# Altium schematic sheet Core.SchDoc
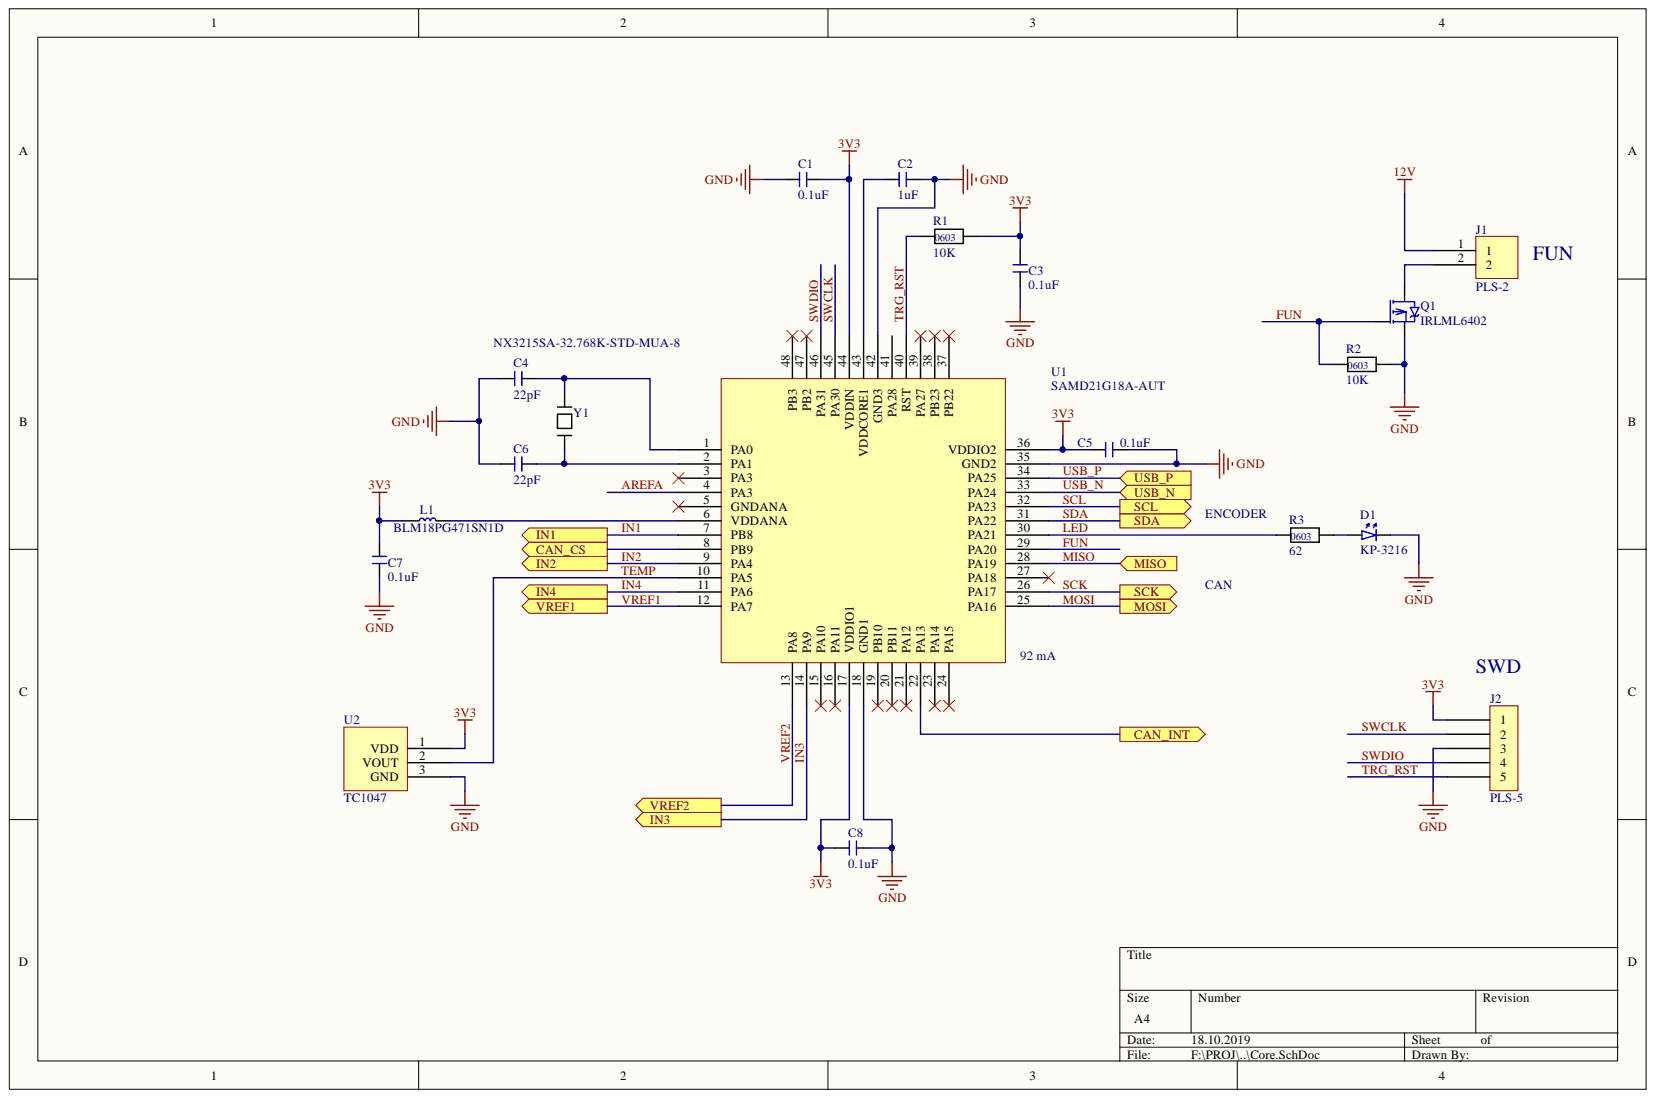
[diagram p0: Core.SchDoc]

## Parts
C1  0.1uF  [CHIP_C_0603]
C2  1uF  [CHIP_C_0603]
C3  0.1uF  [CHIP_C_0603]
C4  22pF  [CHIP_C_0603]
C5  0.1uF  [CHIP_C_0603]
C6  22pF  [CHIP_C_0603]
C7  0.1uF  [CHIP_C_0603]
C8  0.1uF  [CHIP_C_0603]
D1  KP-3216  [CHIP_D_1632]
J1  PLS-2  [PLS-2]
J2  PLS-5  [PLS2-5]
L1  BLM18PG471SN1D  [CHIP_R_0603]
Q1  IRLML6402  [SOT23]
R1  10K  [CHIP_R_0603]
R2  10K  [CHIP_R_0603]
R3  62  [CHIP_R_0603]
U1  SAMD21G18A-AUT  [LQFP48]
U2  TC1047  [SOT23]
Y1  NX3215SA-32.768K-STD-MUA-8  [NX3215SA]

## Ports
CAN_CS, CAN_INT, IN1, IN2, IN3, IN4, MISO, MOSI, SCK, SCL, SDA, USB_N, USB_P, VREF1, VREF2

## Nets
3V3: C1.2 C3.2 C5.1 C7.2 C8.1 J2.1 L1.1 R1.2 U1.17(VDDIO1) U1.36(VDDIO2) U1.44(VDDIN) U2.1
12V: J1.1
GND: C1.1 C2.2 C3.1 C4.1 C5.2 C6.1 C7.1 C8.2 D1.2 J2.3 Q1.2 R2.2 U1.18(GND1) U1.35(GND2) U1.42(GND3) U2.3
AREFA: U1.4(PA3)
CAN_CS: U1.8(PB9) ; port CAN_CS
CAN_INT: U1.22(PA13) ; port CAN_INT
FUN: Q1.1 R2.1 U1.29(PA20)
IN1: U1.7(PB8) ; port IN1
IN2: U1.9(PA4) ; port IN2
IN3: U1.14(PA9) ; port IN3
IN4: U1.11(PA6) ; port IN4
LED: R3.1 U1.30(PA21)
MISO: U1.28(PA19) ; port MISO
MOSI: U1.25(PA16) ; port MOSI
SCK: U1.26(PA17) ; port SCK
SCL: U1.32(PA23) ; port SCL
SDA: U1.31(PA22) ; port SDA
SWCLK: J2.2 U1.45(PA30)
SWDIO: J2.4 U1.46(PA31)
TEMP: U1.10(PA5) U2.2
TRG_RST: J2.5 R1.1 U1.40(RST)
USB_N: U1.33(PA24) ; port USB_N
USB_P: U1.34(PA25) ; port USB_P
VREF1: U1.12(PA7) ; port VREF1
VREF2: U1.13(PA8) ; port VREF2
NetC2_1: C2.1 U1.43(VDDCORE1)
NetC4_2: C4.2 U1.1(PA0) Y1.2
NetC6_2: C6.2 U1.2(PA1) Y1.1
NetD1_1: D1.1 R3.2
NetJ1_2: J1.2 Q1.3
NetL1_2: L1.2 U1.6(VDDANA)

Not connected: U1 3(PA3) 5(GNDANA) 15(PA10) 16(PA11) 19(PB10) 20(PB11) 21(PA12) 23(PA14) 24(PA15) 27(PA18) 37(PB22) 38(PB23) 39(PA27) 41(PA28) 47(PB2) 48(PB3)

## Library data of the parts
C1-C8 = CHIP_C: Component Kind: Standard; Library Name: Popular.SchLib
D1 = KP-3216: LED; Component Kind: Standard; Library Name: Popular.SchLib
J1 = PLS-2: Connector; Component Kind: Standard; Library Name: Popular.SchLib
L1 = BLM: Component Kind: Standard; Library Name: Popular.SchLib
Q1 = IRLML6402: p-chanal MOSFET; Component Kind: Standard; Library Name: Popular.SchLib
R1-R3 = RES_0603: Component Kind: Standard; Library Name: Popular.SchLib
U2 = TC1047: Temperature Sensor; Component Kind: Standard; Library Name: Popular.SchLib
Y1 = Quartz: Component Kind: Standard; Library Name: Popular.SchLib
J2 = PLS-5: Component Kind: Standard; Library Name: Popular.SchLib
U1 = SAMD21G18A-AUT: Component Kind: Standard; Library Name: STM32.SchLib
